# Altium schematic sheet 1.MCU.SchDoc
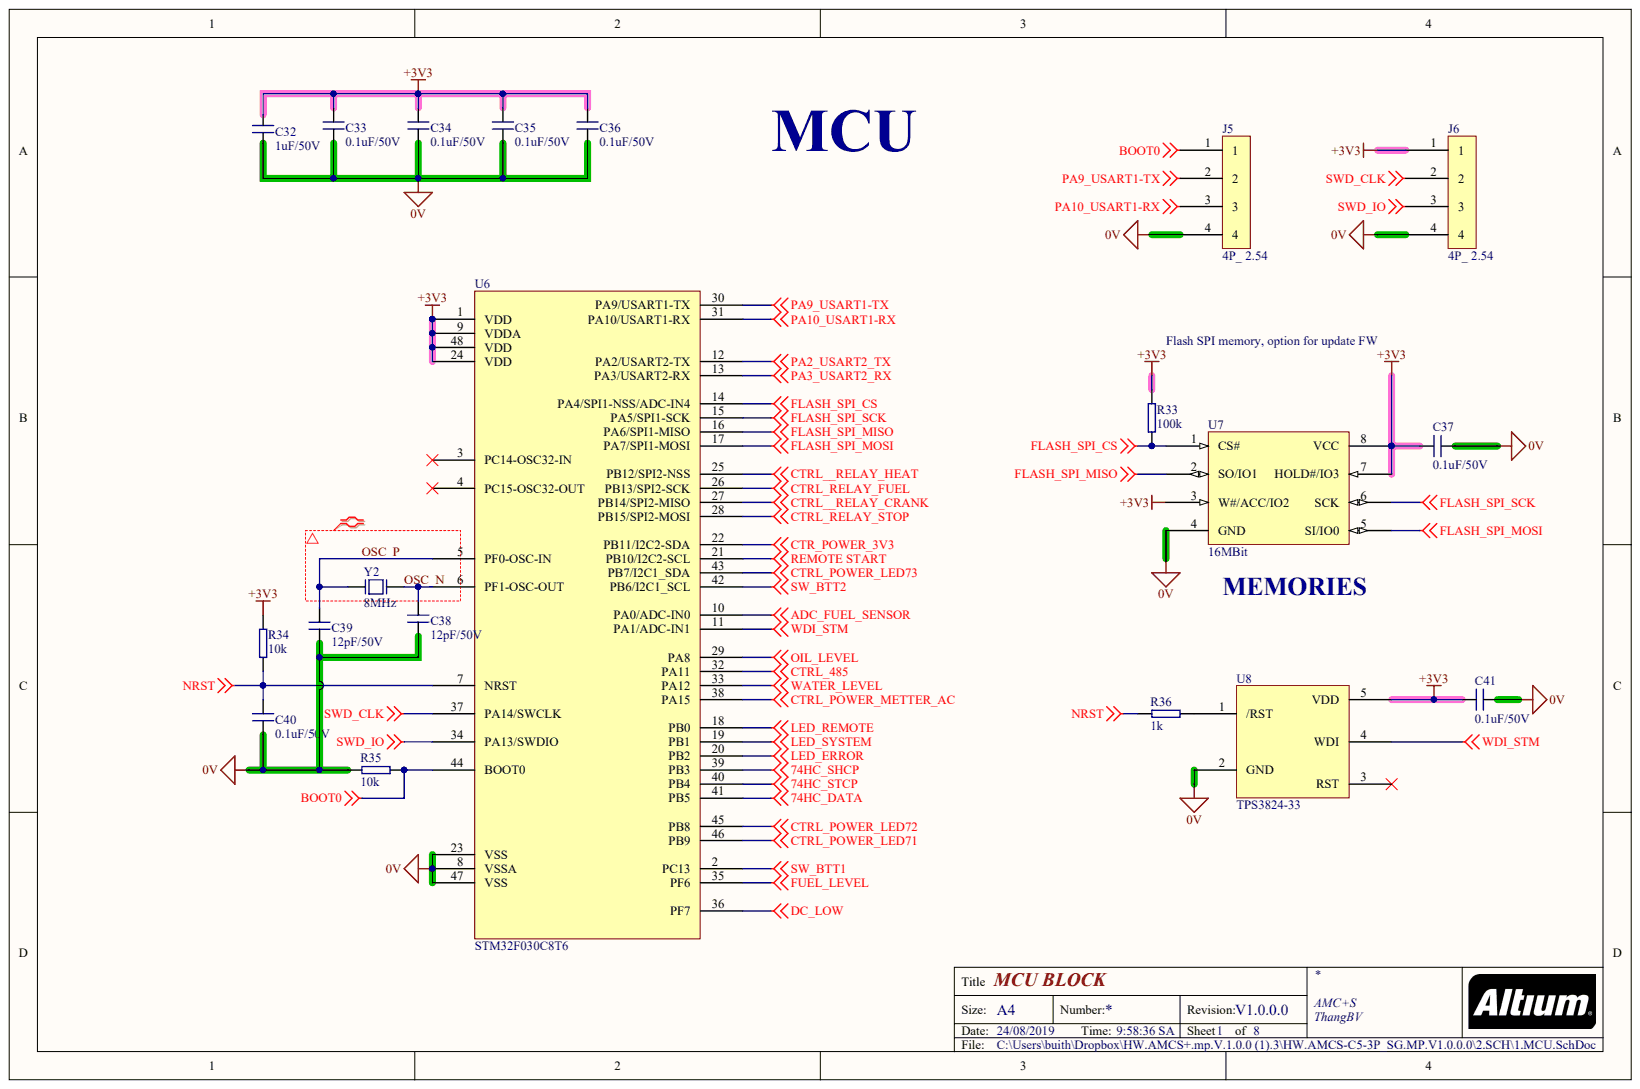
[diagram p0: 1.MCU.SchDoc]

## Parts
C32  1uF/50V  [0805]
C33  0.1uF/50V  [0805]
C34  0.1uF/50V  [0805]
C35  0.1uF/50V  [0805]
C36  0.1uF/50V  [0805]
C37  0.1uF/50V  [0805]
C38  12pF/50V  [0805]
C39  12pF/50V  [0805]
C40  0.1uF/50V  [0805]
C41  0.1uF/50V  [0805]
J5  4P_ 2.54  [HEADER_4x1_2.54]
J6  4P_ 2.54  [HEADER_4x1_2.54]
R33  100k  [0805]
R34  10k  [0805]
R35  10k  [0805]
R36  1k  [0805]
U6  STM32F030C8T6  [LQFP-48_7mx7mx1m6]
U7  16MBit  [SOIC-8-3.9_5.38]
U8  TPS3824-33  [SOT-23-5]
Y2  8MHz  [2-SMD]

## Nets
0V: C32.1 C33.2 C34.2 C35.2 C36.2 C37.2 C38.2 C39.2 C40.2 C41.2 J5.4 J6.4 R35.2 U6.8(VSSA) U6.23(VSS) U6.47(VSS) U7.4(GND) U8.2(GND)
74HC_DATA: U6.41(PB5)
74HC_SHCP: U6.39(PB3)
74HC_STCP: U6.40(PB4)
+3V3: C32.2 C33.1 C34.1 C35.1 C36.1 C37.1 C41.1 J6.1 R33.2 R34.2 U6.1(VDD) U6.9(VDDA) U6.24(VDD) U6.48(VDD) U7.3(W#/ACC/IO2) U7.7(HOLD#/IO3) U7.8(VCC) U8.5(VDD)
ADC_FUEL_SENSOR: U6.10(PA0/ADC-IN0)
BOOT0: J5.1 R35.1 U6.44(BOOT0)
CTR_POWER_3V3: U6.22(PB11/I2C2-SDA)
CTRL_485: U6.32(PA11)
CTRL__RELAY_CRANK: U6.27(PB14/SPI2-MISO)
CTRL__RELAY_HEAT: U6.25(PB12/SPI2-NSS)
CTRL_POWER_LED71: U6.46(PB9)
CTRL_POWER_LED72: U6.45(PB8)
CTRL_POWER_LED73: U6.43(PB7/I2C1_SDA)
CTRL_POWER_METTER_AC: U6.38(PA15)
CTRL_RELAY_FUEL: U6.26(PB13/SPI2-SCK)
CTRL_RELAY_STOP: U6.28(PB15/SPI2-MOSI)
DC_LOW: U6.36(PF7)
FLASH_SPI_CS: R33.1 U6.14(PA4/SPI1-NSS/ADC-IN4) U7.1(CS#)
FLASH_SPI_MISO: U6.16(PA6/SPI1-MISO) U7.2(SO/IO1)
FLASH_SPI_MOSI: U6.17(PA7/SPI1-MOSI) U7.5(SI/IO0)
FLASH_SPI_SCK: U6.15(PA5/SPI1-SCK) U7.6(SCK)
FUEL_LEVEL: U6.35(PF6)
LED_ERROR: U6.20(PB2)
LED_REMOTE: U6.18(PB0)
LED_SYSTEM: U6.19(PB1)
NRST: C40.1 R34.1 R36.1 U6.7(NRST)
OIL_LEVEL: U6.29(PA8)
PA2_USART2_TX: U6.12(PA2/USART2-TX)
PA3_USART2_RX: U6.13(PA3/USART2-RX)
PA9_USART1-TX: J5.2 U6.30(PA9/USART1-TX)
PA10_USART1-RX: J5.3 U6.31(PA10/USART1-RX)
REMOTE START: U6.21(PB10/I2C2-SCL)
SW_BTT1: U6.2(PC13)
SW_BTT2: U6.42(PB6/I2C1_SCL)
SWD_CLK: J6.2 U6.37(PA14/SWCLK)
SWD_IO: J6.3 U6.34(PA13/SWDIO)
WATER_LEVEL: U6.33(PA12)
WDI_STM: U6.11(PA1/ADC-IN1) U8.4(WDI)
OSC_N: C38.1 U6.6(PF1-OSC-OUT) Y2.2
OSC_P: C39.1 U6.5(PF0-OSC-IN) Y2.1
NetR36_2: R36.2 U8.1(/RST)
N00027:

Not connected: U6 3(PC14-OSC32-IN) 4(PC15-OSC32-OUT); U8 3(RST)

## Library data of the parts
C32 = Cap_1uF_0805: CAP CER 0.1UF 50V Y5V 0805; MFR: Yageo; Part Number: CC0805ZRY5V9BB104; Vender Part: 311-1361-2-ND; AMCS CODE: VT-CAP-0004; ASS: SMT; Capacitance: 0.1µF; Tolerance: -20%, +80%; Vender: Digi-Key; Component Kind: Standard; Operating Temperature: -30°C ~ 85°C; Package: 0805 (2012 Metric); TYPE: CAP; Voltage - Rated: 50V; Library Name: Schlib_Diepvn_V01.SchLib
C33-C37 C40 C41 = Cap_0.1uF: CAP CER 0.1UF 50V Y5V 0805; MFR: Yageo; Part Number: CC0805ZRY5V9BB104; AMCS CODE: VT-CAP-0004; ASS: SMT; Component Kind: Standard; Package: 0805; Library Name: Schlib_Diepvn_V01.SchLib
C38 C39 = Cap_12p: CAP CER 12PF 50V C0G/NPO 0805; MFR: Yageo; Part Number: CC0805JRNPO9BN120; AMCS CODE: VT-CAP-0001; Component Kind: Standard; Package: 0805; Library Name: Schlib_Diepvn_V01.SchLib
J5 J6 = Header 4x1: 4P Pitch:2.54mm; ASS: TH; NOTES: PITCH 2.54 _ JUMPER 40P; Component Kind: Standard; TYPE: CON; Library Name: Schlib_Diepvn_V01.SchLib
R33 = Res_100k: RES 100K OHM 1/10W 1% 0805 SMD; MFR: Yageo; Part Number: RC0805FR-07100KL; AMCS CODE: VT-RES-0005; ASS: SMT; Component Kind: Standard; Package: 0805; Library Name: Schlib_Diepvn_V01.SchLib
R34 R35 = Res_10k: RES SMD 10K OHM 1% 1/8W 0805; MFR: Yageo; Part Number: RC0805FR-0710KL; AMCS CODE: VT-RES-0001; ASS: SMT; Component Kind: Standard; Package: 0805; Library Name: Schlib_Diepvn_V01.SchLib
R36 = Res_1k_0805: RES SMD 1K OHM 1% 1/8W 0805; MFR: Yageo; Part Number: RC0805FR-071KL; Vender PartNumber: 311-1.00KCRTR-ND; AMCS CODE: VT-RES-0003; ASS: SMT; Detailed Description: 1 kOhms ±1% 0.125W, 1/8W Chip Resistor 0805 (2012 Metric) Moisture Resistant Thick Film; Power (Watts): 0.125W, 1/8W; Resistance: 1 kOhms; Temperature Coefficient: ±100ppm/°C; Vender: Digi-Key; Component Kind: Standard; Operating Temperature: -55°C ~ 155°C; Package: 0805 (2012 Metric); Size / Dimension: 0.079" L x 0.049" W (2.00mm x 1.25mm); Tolerance: ±1%; TYPE: RES; Library Name: Schlib_Diepvn_V01.SchLib
U6 = STM32F030C8T6: IC MCU ARM 64K FLASH 48LQFP; MFR: ST; Vender Part: 497-14043-ND; AMCS CODE: VT-IC-0014; ASS: SMT; Component Kind: Standard; Package: LQFP-48; Part Number: STM32F030C8T6; TYPE: IC; Library Name: Schlib_Diepvn_V01.SchLib
U7 = S25FL256SA: IC FLASH 16MBIT 104MHZ 8-SOIC; MFR: GDE; Part Number: GD25Q64CSIG; Part Number 1: GD25Q16CTIGR; AMCS CODE: VT-IC-0003; ASS: SMT; Component Kind: Standard; Package: 8-SOIC (0.154", 5.30mm Width); TYPE: IC; Library Name: Schlib_Diepvn_V01.SchLib
U8 = TPS3824-33: IC 2.93V SUPPLY MONITOR SOT-23-5; MFR: TI; Part Number: TPS3824-33DBVR; AMCS CODE: VT-IC-0011; Component Kind: Standard; Package: SOT23-5; Library Name: Schlib_Diepvn_V01.SchLib
Y2 = CRY_8MHZ: CRYSTAL 8.0000MHZ 18PF SMD; MFR: Abracon; Part Number: ABM3-8.000MHZ-D2Y-T; AMCS CODE: VT-IC-0017; Component Kind: Standard; Package: 2-SMD; Library Name: Schlib_Diepvn_V01.SchLib
